# Altium schematic sheet MCU.SchDoc(MCU)
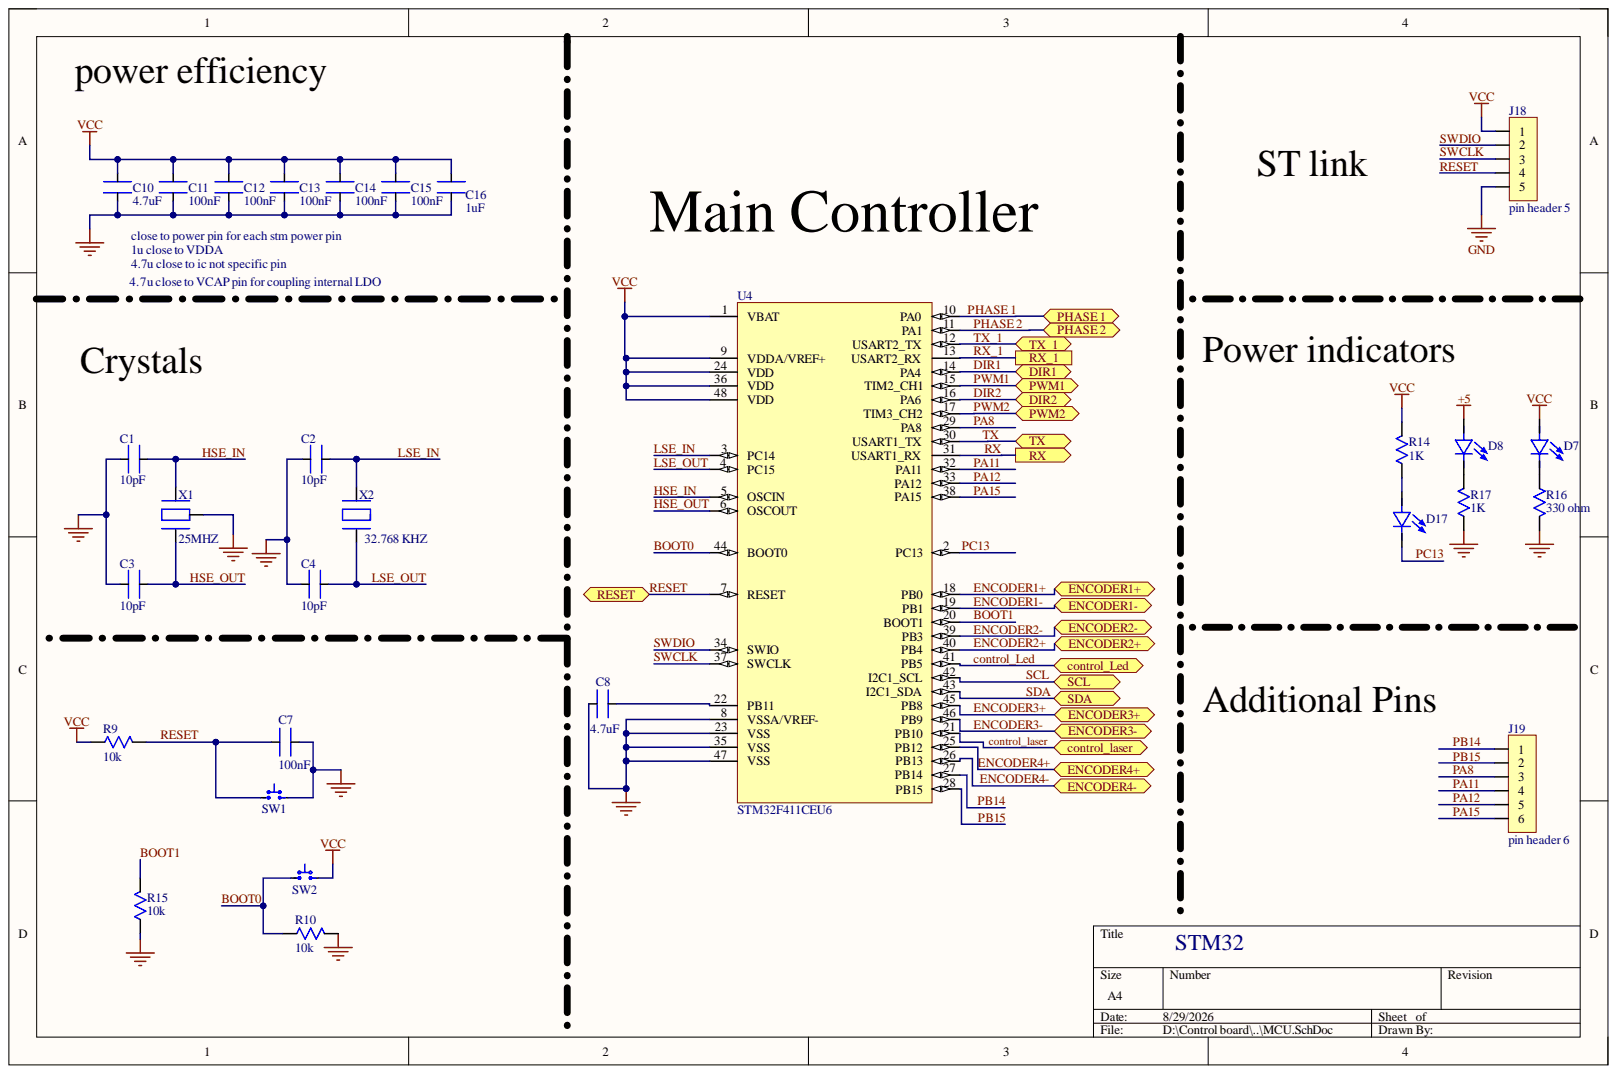
[diagram p0: MCU.SchDoc]

## Parts
C1  10pF  [0603 CAP]
C2  10pF  [0603 CAP]
C3  10pF  [0603 CAP]
C4  10pF  [0603 CAP]
C7  100nF  [0603 CAP]
C8  4.7uF  [0603 CAP]
C10  4.7uF  [0603 CAP]
C11  100nF  [0603 CAP]
C12  100nF  [0603 CAP]
C13  100nF  [0603 CAP]
C14  100nF  [0603 CAP]
C15  100nF  [0603 CAP]
C16  1uF  [0603 CAP]
D7  RED led  [led 0805]  (value not drawn)
D8  RED led  [led 0805]  (value not drawn)
D17  RED led  [led 0805]  (value not drawn)
J18  pin header 5  [pin header 5 pin]
J19  pin header 6  [pin header 6 pin]
R9  10k  [0603 RES]
R10  10k  [0603 RES]
R14  1K  [0603 RES]
R15  10k  [0603 RES]
R16  330 ohm  [0603 RES]
R17  1K  [0603 RES]
SW1  push button  [Push button]  (value not drawn)
SW2  push button  [Push button]  (value not drawn)
U4  STM32F411CEU6  [Stm32 UFQFPN-48]
X1  25MHZ  [25MHZ Crystal Oscillators]
X2  32.768 KHZ  [32.768 KHZ Crystal oscillator]

## Ports
control_laser, control_Led, DIR1, DIR2, ENCODER1+, ENCODER1-, ENCODER2+, ENCODER2-, ENCODER3+, ENCODER3-, ENCODER4+, ENCODER4-, PHASE 1, PHASE 2, PWM1, PWM2, RESET, RX, RX_1, SCL, SDA, TX, TX_1

## Nets
+5: D8.1
GND: C1.2 C2.2 C3.2 C4.2 C7.1 C8.2 C10.2 C11.2 C12.2 C13.2 C14.2 C15.2 C16.2 J18.5 R10.2 R15.1 R16.2 R17.1 SW1.2 U4.8(VSSA/VREF-) U4.23(VSS) U4.35(VSS) U4.47(VSS) X1.2
VCC: C10.1 C11.1 C12.1 C13.1 C14.1 C15.1 C16.1 D7.1 J18.1 R9.1 R14.2 SW2.2 U4.1(VBAT) U4.9(VDDA/VREF+) U4.24(VDD) U4.36(VDD) U4.48(VDD)
BOOT0: R10.1 SW2.1 U4.44(BOOT0)
BOOT1: R15.2 U4.20(BOOT1)
control_laser: U4.21(PB10) ; port control_laser
control_Led: U4.41(PB5) ; port control_Led
DIR1: U4.14(PA4) ; port DIR1
DIR2: U4.16(PA6) ; port DIR2
ENCODER1+: U4.18(PB0) ; port ENCODER1+
ENCODER1-: U4.19(PB1) ; port ENCODER1-
ENCODER2+: U4.40(PB4) ; port ENCODER2+
ENCODER2-: U4.39(PB3) ; port ENCODER2-
ENCODER3+: U4.45(PB8) ; port ENCODER3+
ENCODER3-: U4.46(PB9) ; port ENCODER3-
ENCODER4+: U4.25(PB12) ; port ENCODER4+
ENCODER4-: U4.26(PB13) ; port ENCODER4-
HSE_IN: C1.1 U4.5(OSCIN) X1.1
HSE_OUT: C3.1 U4.6(OSCOUT) X1.3
LSE_IN: C2.1 U4.3(PC14) X2.1
LSE_OUT: C4.1 U4.4(PC15) X2.2
PA8: J19.3 U4.29(PA8)
PA11: J19.4 U4.32(PA11)
PA12: J19.5 U4.33(PA12)
PA15: J19.6 U4.38(PA15)
PB14: J19.1 U4.27(PB14)
PB15: J19.2 U4.28(PB15)
PC13: D17.2 U4.2(PC13)
PHASE 1: U4.10(PA0) ; port PHASE 1
PHASE 2: U4.11(PA1) ; port PHASE 2
PWM1: U4.15(TIM2_CH1) ; port PWM1
PWM2: U4.17(TIM3_CH2) ; port PWM2
RESET: C7.2 J18.4 R9.2 SW1.1 U4.7(RESET) ; port RESET
RX: U4.31(USART1_RX) ; port RX
RX_1: U4.13(USART2_RX) ; port RX_1
SCL: U4.42(I2C1_SCL) ; port SCL
SDA: U4.43(I2C1_SDA) ; port SDA
SWCLK: J18.3 U4.37(SWCLK)
SWDIO: J18.2 U4.34(SWIO)
TX: U4.30(USART1_TX) ; port TX
TX_1: U4.12(USART2_TX) ; port TX_1
NetC8_1: C8.1 U4.22(PB11)
NetD7_2: D7.2 R16.1
NetD8_2: D8.2 R17.2
NetD17_1: D17.1 R14.1

## Library data of the parts
C1-C4 = 10pF: 50V 10pF NP0 ±5% 0603 Multilayer Ceramic Capacitors MLCC - SMD/SMT ROHS; Manufacturer 1: YAGEO; Manufacturer Part Number 1: CC0603JRNPO9BN100; Component Kind: Standard; Library Name: Schlib1.SchLib; Link: https://www.lcsc.com/product-detail/Multilayer-Ceramic-Capacitors-MLCC-SMD-SMT_YAGEO-CC0603JRNPO9BN100_C106245.html; Supplier 1: LCSC; Supplier Part Number 1: C106245
C7 C11-C15 = 100nF: 50V 100nF X7R ±10% 0603 Multilayer Ceramic Capacitors MLCC - SMD/SMT ROHS; Manufacturer 1: YAGEO; Manufacturer Part Number 1: CC0603KRX7R9BB104; Component Kind: Standard; Library Name: Schlib1.SchLib; Link: https://www.lcsc.com/product-detail/Multilayer-Ceramic-Capacitors-MLCC-SMD-SMT_YAGEO-CC0603KRX7R9BB104_C14663.html; Supplier 1: LCSC; Supplier Part Number 1: C14663
C8 C10 = 4.7uF: 6.3V 4.7uF X5R ±20% 0603 Multilayer Ceramic Capacitors MLCC - SMD/SMT ROHS; Manufacturer 1: Samsung Electro-Mechanics; Manufacturer Part Number 1: CL10A475MQ8NNNC; Component Kind: Standard; Library Name: Schlib1.SchLib; Link: https://www.lcsc.com/product-detail/Multilayer-Ceramic-Capacitors-MLCC-SMD-SMT_Samsung-Electro-Mechanics-CL10A475MQ8NNNC_C154959.html?s_z=n_6.3V%25204.7uF%2520X5R%2520%25C2%25B120%2525%25200603%2520Multilayer%2520Ceramic%2520Capacitors%2520MLCC%2520-%2520SMD%252FSMT%2520ROHS; Supplier 1: LCSC; Supplier Part Number 1: C154959
C16 = 1uF: 16V 1uF X5R ±10% 0603 Multilayer Ceramic Capacitors MLCC - SMD/SMT ROHS; Manufacturer 1: HRE; Manufacturer Part Number 1: CGA0603X5R105K160JT; Component Kind: Standard; Library Name: Schlib1.SchLib; Link: https://www.lcsc.com/product-detail/Multilayer-Ceramic-Capacitors-MLCC-SMD-SMT_HRE-CGA0603X5R105K160JT_C6119849.html; Supplier 1: LCSC; Supplier Part Number 1: C6119849
D7 D8 D17 = RED LED: 20mA 8mcd Colorless Transparent Lens 617nm Red 2V 0805 LED Indication - Discrete ROHS; Manufacturer 1: Kingbright; Manufacturer Part Number 1: APT2012EC; Component Kind: Standard; Library Name: Schlib1.SchLib; Link: https://www.lcsc.com/product-detail/LED-Indication-Discrete_Kingbright-APT2012EC_C5879378.html; Supplier 1: LCSC; Supplier Part Number 1: C5879378
J18 = pin header 5: 5P 1x5P Brass -40℃~+105℃ Black Pin Header 2.54mm 2.5mm 6mm 3mm 1 Through Hole Through Hole,P=2.54mm Headers, Male Pins RoHS; Manufacture part number 1: PZ254V-11-05P; Manufacturer 1: XFCN; Component Kind: Standard; Library Name: Schlib1.SchLib; Link: https://www.lcsc.com/product-detail/C492404.html; Supplier 1: LCSC; Supplier Part Number 1: C492404
J19 = pin header 6: Straight Policy 2.5mm 6P 6mm -40℃~+105℃ 3mm 2.54mm Single Row Black Brass 1x6P Plugin,P=2.54mm Pin Headers ROHS; Manufacture part number 1: PZ254V-11-06P; Manufacturer 1: XFCN; Component Kind: Standard; Library Name: Schlib1.SchLib; Link: https://www.lcsc.com/product-detail/Pin-Headers_XFCN-PZ254V-11-06P_C492405.html?s_z=n_Connectors%257CPin%2520Headers; Supplier 1: LCSC; Supplier part number 1: C492405
R9 R10 R15 = RES 10kohm: 100mW Thin Film Resistor 75V ±25ppm/℃ ±0.1% 10kΩ 0603 Chip Resistor - Surface Mount ROHS; Manufacturer 1: YAGEO; Manufacturer Part Number 1: RT0603BRD0710KL; Component Kind: Standard; Library Name: Schlib1.SchLib; Link: https://www.lcsc.com/product-detail/Chip-Resistor-Surface-Mount_YAGEO-RT0603BRD0710KL_C95204.html?s_z=n_100mW%2520Thin%2520Film%2520Resistor%252075V%2520%25C2%25B125ppm%252F%25E2%2584%2583%2520%25C2%25B10.1%2525%252010k%25CE%25A9%25200603%2520Chip%2520Resistor%2520-%2520Surface%2520Mount%2520ROHS; Supplier 1: LCSC; Supplier Part Number 1: C95204
R14 R17 = RES 1K ohm: 100mW Thick Film Resistors 75V ±100ppm/℃ ±5% 1kΩ 0603 Chip Resistor - Surface Mount ROHS; Manufacturer 1: FOJAN; Manufacturer Part Number 1: FRC0603J102 TS; Component Kind: Standard; Library Name: Schlib1.SchLib; Link: https://www.lcsc.com/product-detail/Chip-Resistor-Surface-Mount_FOJAN-FRC0603J102-TS_C2907113.html; Supplier 1: LCSC; Supplier Part Number 1: C2907113
R16 = RES 330 ohm: 100mW Thick Film Resistors 75V ±100ppm/℃ ±5% 330Ω 0603 Chip Resistor - Surface Mount ROHS; Manufacturer 1: FOJAN; Manufacturer Part Number 1: FRC0603J331 TS; Component Kind: Standard; Library Name: Schlib1.SchLib; Link: https://www.lcsc.com/product-detail/Chip-Resistor-Surface-Mount_FOJAN-FRC0603J331-TS_C2907152.html; Supplier 1: LCSC; Supplier Part Number 1: C2907152
SW1 SW2 = push button: 12V Round Button SPST 50mA SMD-4P,6x6mm Tactile Switches RoHS; Manufacturer 1: CUI; Manufacturer Part Number 1: TS04-66-50-BK-160-SMT; Component Kind: Standard; Library Name: Schlib1.SchLib; Link: https://www.lcsc.com/product-detail/C4364861.html?s_z=s_Switches%257CTactile%2520Switches; Supplier 1: LCSC; Supplier Part Number 1: C4364861
U4 = STMicroelectronics STM32F411CEU6: ARM-M4 100MHz UFQFPN-48(7x7) Microcontrollers (MCU/MPU/SOC) ROHS; Component Kind: Standard; Library Name: Schlib1.SchLib; Link: https://www.lcsc.com/product-detail/Microcontrollers-MCU-MPU-SOC_STMicroelectronics-STM32F411CEU6_C60420.html?s_z=n_STM32F411CEU6; Manufacturer 1: STMicroelectronics; Manufacturer Part Number 1: STM32F411CEU6; Supplier 1: LCSC; Supplier Part Number 1: C60420
X1 = 25MHZ Crystal oscillator: 25MHz Surface Mount Crystal 8pF ±10ppm ±30ppm SMD3225-4P Crystals ROHS; Manufacturer 1: Shenzhen SCTF Elec; Manufacturer Part Number 1: SX3B25.000F0810G30; Component Kind: Standard; Library Name: Schlib1.SchLib; Link: https://www.lcsc.com/product-detail/Crystals_Shenzhen-SCTF-Elec-SX3B25-000F0810G30_C7302032.html; Supplier 1: LCSC; Supplier Part Number 1: C7302032
X2 = 32.768 KHZ Crystal oscillator: 32.768kHz Surface Mount Crystal 7pF ±20ppm SMD2012-2P Crystals ROHSS.; Manufacturer 1: Seiko Epson; Manufacturer Part Number 1: X1A0000610006; Component Kind: Standard; Library Name: Schlib1.SchLib; Link: https://www.lcsc.com/product-detail/Crystals_Seiko-Epson-X1A0000610006_C99009.html; Supplier 1: LCSC; Supplier Part Number 1: C99009
